# Altium schematic sheet USB.SchDoc
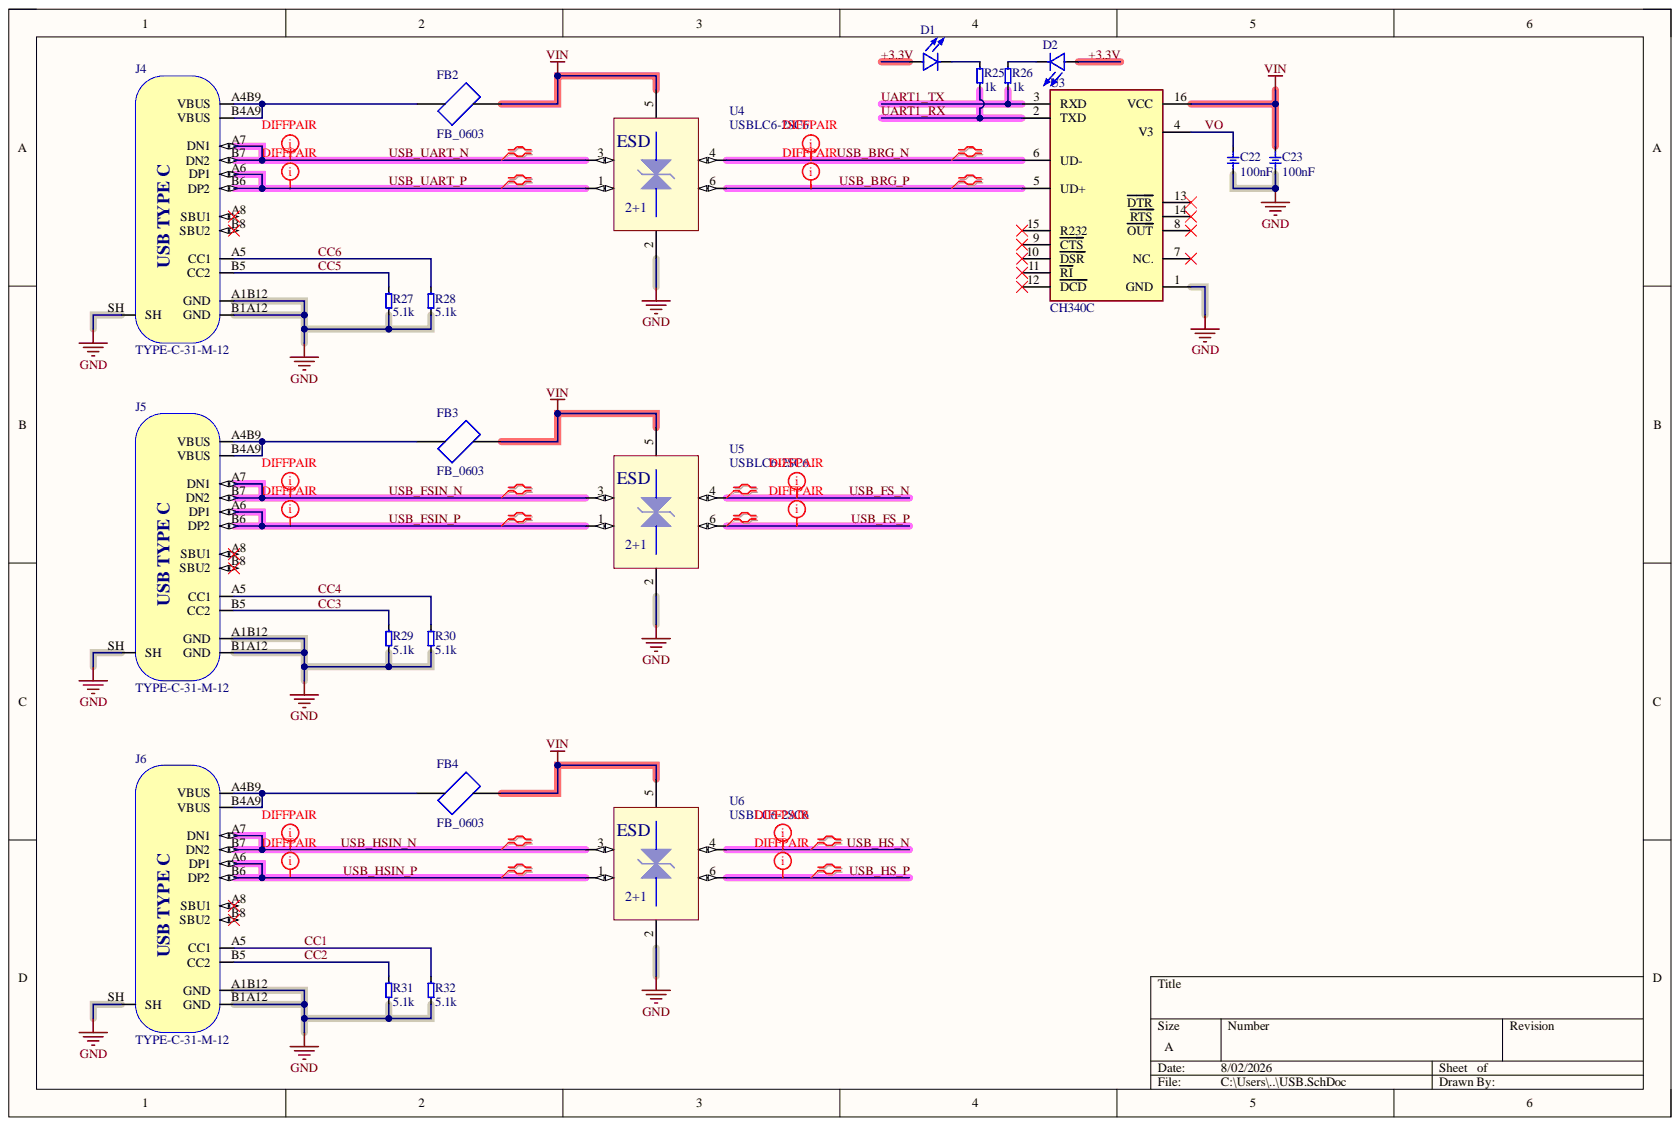
[diagram p0: USB.SchDoc]

## Parts
C22  100nF  [CAP_0603]
C23  100nF  [CAP_0603]
D1  LED_0603  [LED_0603]  (value not drawn)
D2  LED_0603  [LED_0603]  (value not drawn)
FB2  FB_0603  [FB_0603]
FB3  FB_0603  [FB_0603]
FB4  FB_0603  [FB_0603]
J4  TYPE-C-31-M-12  [TYPE-C-31-M-12]
J5  TYPE-C-31-M-12  [TYPE-C-31-M-12]
J6  TYPE-C-31-M-12  [TYPE-C-31-M-12]
R25  1k  [RES_0603]
R26  1k  [RES_0603]
R27  5.1k  [RES_0603]
R28  5.1k  [RES_0603]
R29  5.1k  [RES_0603]
R30  5.1k  [RES_0603]
R31  5.1k  [RES_0603]
R32  5.1k  [RES_0603]
U3  CH340C  [CH340C]
U4  USBLC6-2SC6  [SOT-23-6]
U5  USBLC6-2SC6  [SOT-23-6]
U6  USBLC6-2SC6  [SOT-23-6]

## Nets
GND: C22.1 C23.1 J4.A1B12(GND) J4.B1A12(GND) J4.SH J5.A1B12(GND) J5.B1A12(GND) J5.SH J6.A1B12(GND) J6.B1A12(GND) J6.SH R27.1 R28.1 R29.1 R30.1 R31.1 R32.1 U3.1(GND) U4.2 U5.2 U6.2
VIN: C23.2 FB2.2 FB3.2 FB4.2 U3.16(VCC) U4.5 U5.5 U6.5
+3.3V: D1.A D2.A
CC1: J6.A5(CC1) R32.2
CC2: J6.B5(CC2) R31.2
CC3: J5.B5(CC2) R29.2
CC4: J5.A5(CC1) R30.2
CC5: J4.B5(CC2) R27.2
CC6: J4.A5(CC1) R28.2
UART1_RX: R25.1 U3.2(TXD)
UART1_TX: R26.1 U3.3(RXD)
USB_BRG_N: U3.6(UD-) U4.4
USB_BRG_P: U3.5(UD+) U4.6
USB_FS_N: U5.4
USB_FS_P: U5.6
USB_FSIN_N: J5.A7(DN1) J5.B7(DN2) U5.3
USB_FSIN_P: J5.A6(DP1) J5.B6(DP2) U5.1
USB_HS_N: U6.4
USB_HS_P: U6.6
USB_HSIN_N: J6.A7(DN1) J6.B7(DN2) U6.3
USB_HSIN_P: J6.A6(DP1) J6.B6(DP2) U6.1
USB_UART_N: J4.A7(DN1) J4.B7(DN2) U4.3
USB_UART_P: J4.A6(DP1) J4.B6(DP2) U4.1
VO: C22.2 U3.4(V3)
NetD1_C: D1.C R25.2
NetD2_C: D2.C R26.2
NetFB2_1: FB2.1 J4.A4B9(VBUS) J4.B4A9(VBUS)
NetFB3_1: FB3.1 J5.A4B9(VBUS) J5.B4A9(VBUS)
NetFB4_1: FB4.1 J6.A4B9(VBUS) J6.B4A9(VBUS)

Not connected: J4 A8(SBU1) B8(SBU2); J5 A8(SBU1) B8(SBU2); J6 A8(SBU1) B8(SBU2); U3 7(NC.) 8(OUT) 9(CTS) 10(DSR) 11(RI) 12(DCD) 13(DTR) 14(RTS) 15(R232)

## Library data of the parts
C22 C23 = CAP_0603: Component Kind: Standard; Library Name: RQSchlib.SchLib
D1 D2 = LED_0603: LED SMD 0603 Ultra Yellow 593nm 70mcd Water Clear Lens 130 Deg Viewing Angle | Bivar Inc. SM0603UYC; Check_prices: https://www.snapeda.com/parts/SM0603UYC/Bivar/view-part/?ref=eda; Component Kind: Standard; MF: Bivar; MP: SM0603UYC; Package: 0603 Bivar; SnapEDA_Link: https://www.snapeda.com/parts/SM0603UYC/Bivar/view-part/?ref=snap; Library Name: RQSchlib.SchLib
FB2-FB4 = FB_0603: Ferrite Bead | 1200 Ohm | 0603 1LN; MF: Signal Transformer; MP: FCB-0603-122A; Availability: Not in stock; Check_prices: https://www.snapeda.com/parts/FCB-0603-122A/Signal+Transformer/view-part/?ref=eda; Component Kind: Standard; Package: None; Price: None; SnapEDA_Link: https://www.snapeda.com/parts/FCB-0603-122A/Signal+Transformer/view-part/?ref=snap; Library Name: RQSchlib.SchLib
J4-J6 = TYPE-C-31-M-12_1: USB Type C Connector Horizontal SMT; Manufacturer: Koren Hroparts; Component Kind: Standard; Manufacturer Part Number: TYPE-C-31-M-12; Library Name: RQSchlib.SchLib
R25-R32 = RES_0603: Component Kind: Standard; Library Name: RQSchlib.SchLib
U3 = CH340C: USB to serial chip CH340; MF: WCH; Availability: Not in stock; Check_prices: https://www.snapeda.com/parts/CH340C/WCH/view-part/?ref=eda; Component Kind: Standard; MP: CH340C; Package: None; Price: None; SnapEDA_Link: https://www.snapeda.com/parts/CH340C/WCH/view-part/?ref=snap; Library Name: RQSchlib.SchLib
U4-U6 = USBLC6-2SC6: 17V Clamp 5A (8/20Âµs) Ipp Tvs Diode Surface Mount SOT-23-6; MF: STMicroelectronics; Availability: In Stock; Check_prices: https://www.snapeda.com/parts/USBLC6-2SC6/STMicroelectronics/view-part/?ref=eda; Component Kind: Standard; MP: USBLC6-2SC6; Package: SOT-23-6 STMicroelectronics; Price: None; Purchase-URL: https://pricing.snapeda.com/search/part/USBLC6-2SC6/?ref=eda; SnapEDA_Link: https://www.snapeda.com/parts/USBLC6-2SC6/STMicroelectronics/view-part/?ref=snap; Library Name: RQSchlib.SchLib
